# Altium schematic sheet Sheet1.SchDoc
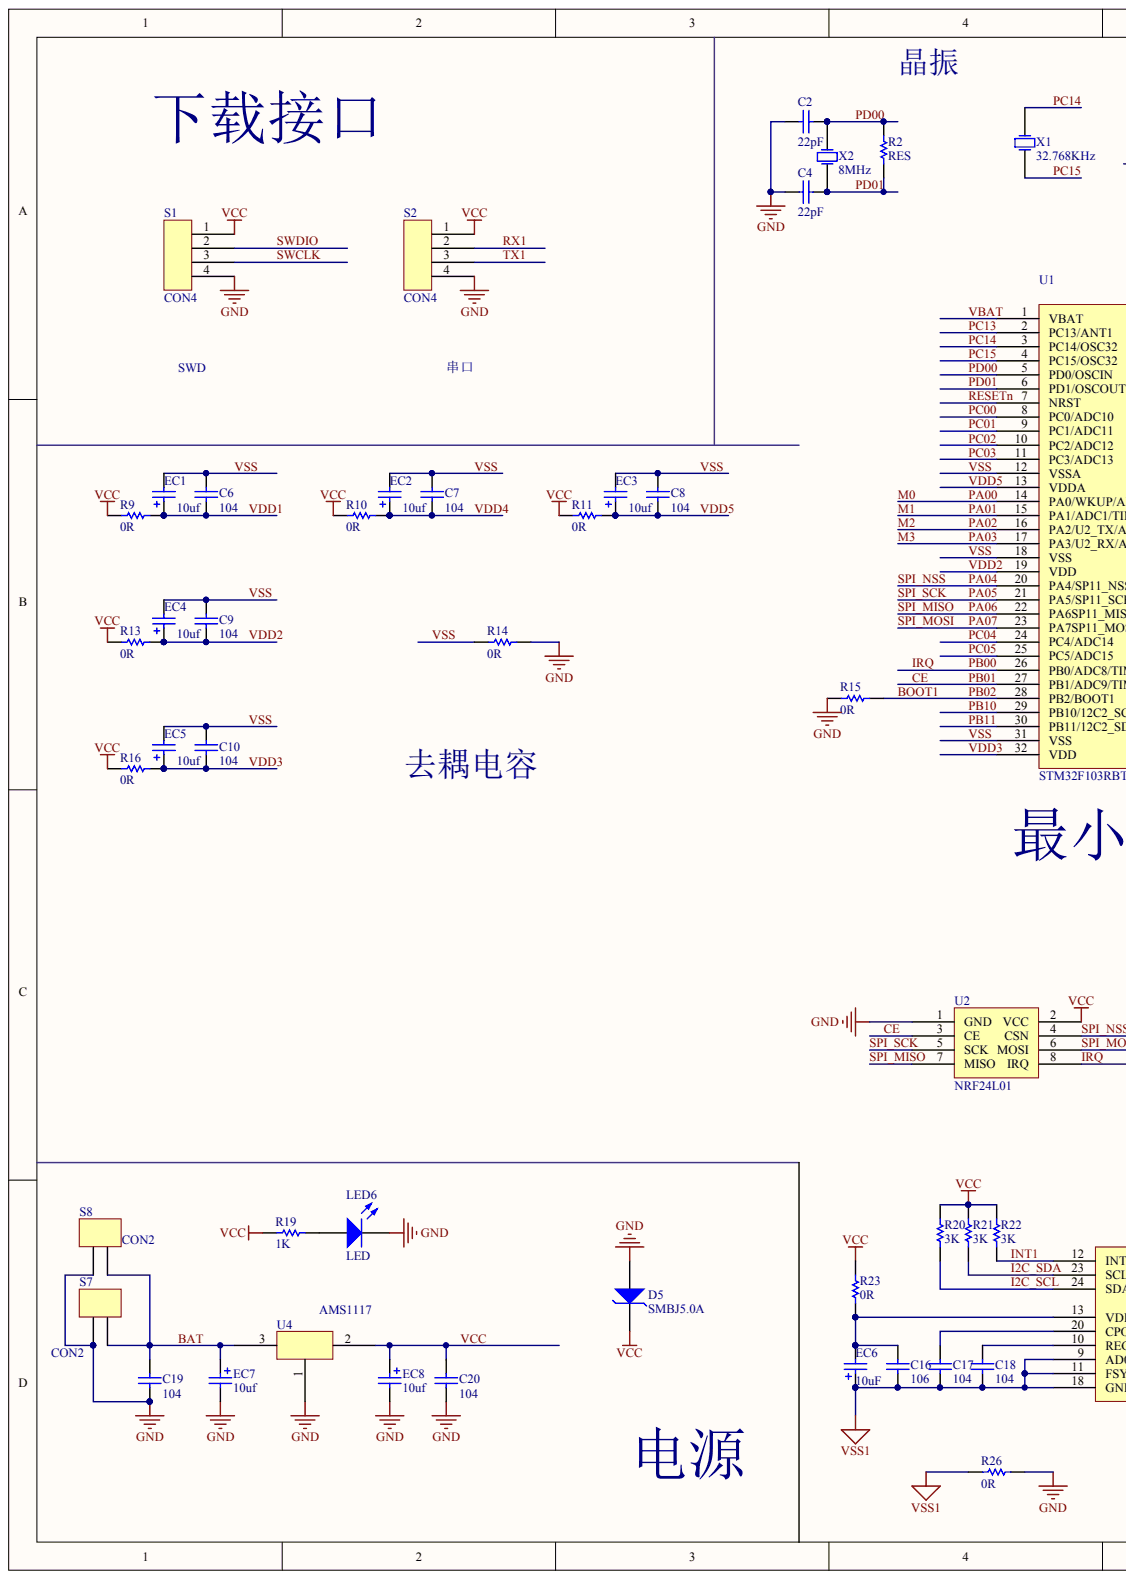
[diagram p0: Sheet1.SchDoc, left]
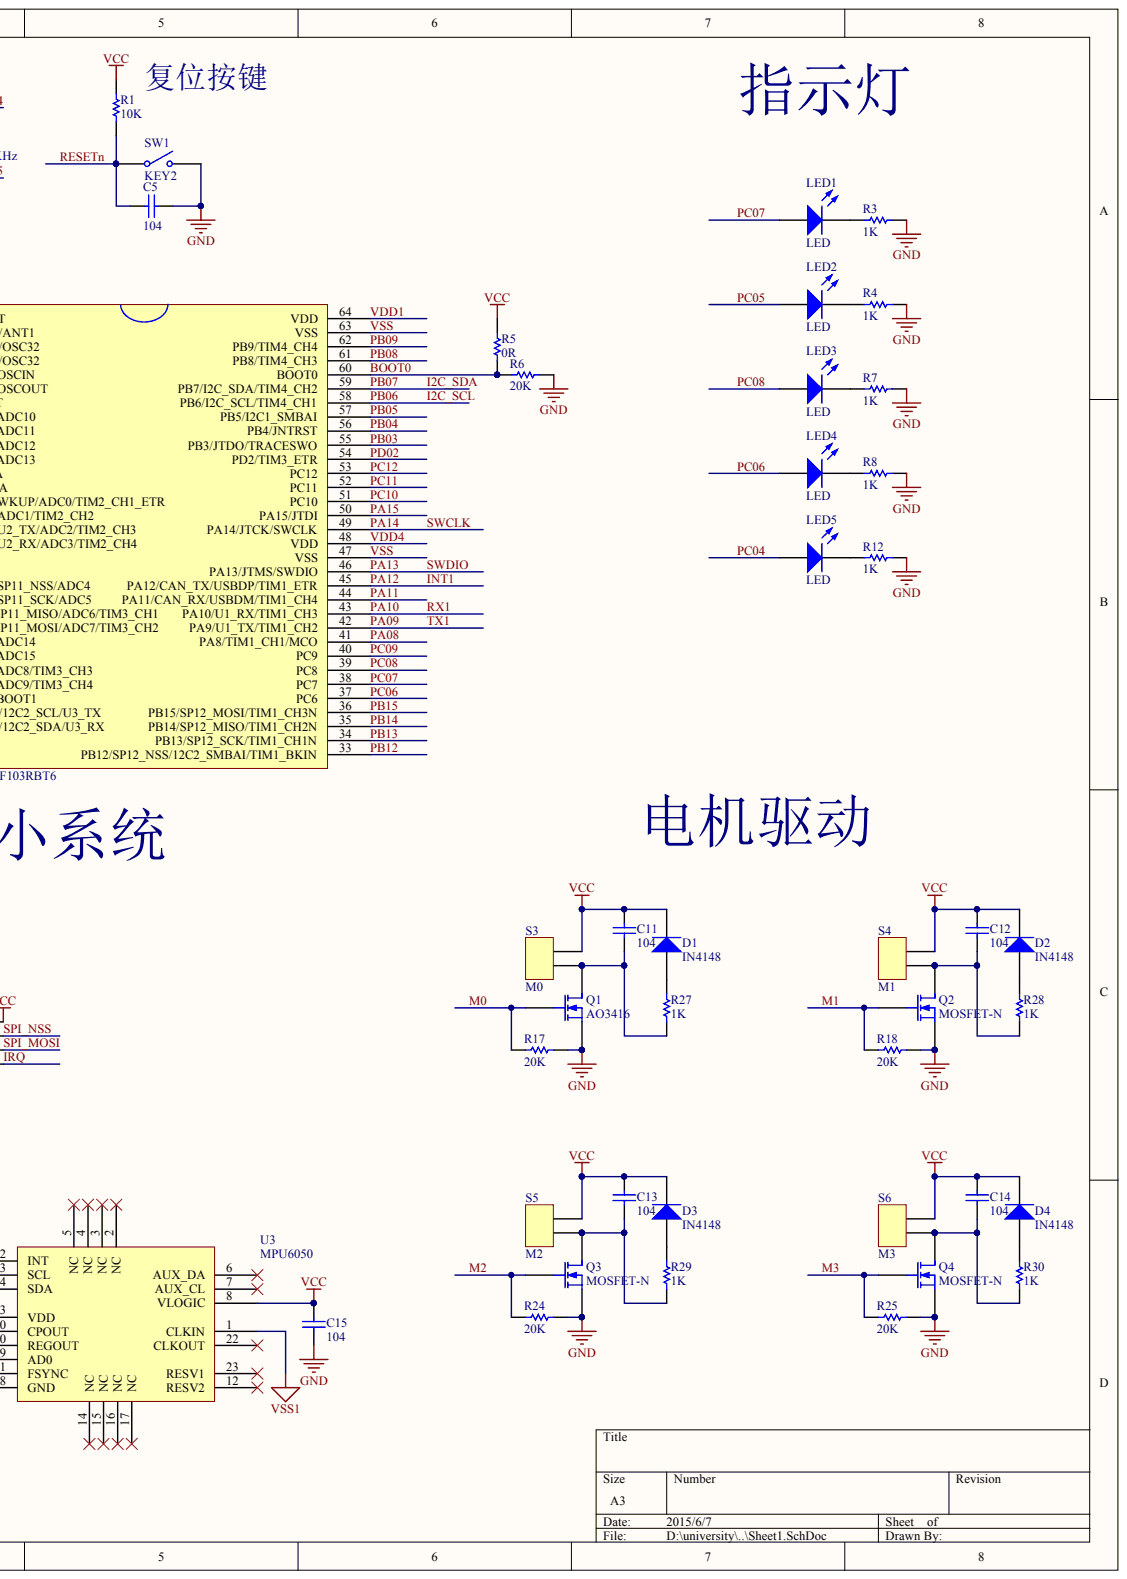
[diagram p1: Sheet1.SchDoc, right]

## Parts
C2  22pF
C4  22pF
C5  104
C6  104
C7  104
C8  104
C9  104
C10  104
C11  104
C12  104
C13  104
C14  104
C15  104
C16  106
C17  104
C18  104
C19  104
C20  104
D1  IN4148
D2  IN4148
D3  IN4148
D4  IN4148
D5  SMBJ5.0A
EC1  10uf
EC2  10uf
EC3  10uf
EC4  10uf
EC5  10uf
EC6  10uF
EC7  10uf
EC8  10uf
LED1  LED
LED2  LED
LED3  LED
LED4  LED
LED5  LED
LED6  LED
Q1  AO3416
Q2  MOSFET-N
Q3  MOSFET-N
Q4  MOSFET-N
R1  10K
R2  RES
R3  1K
R4  1K
R5  0R
R6  20K
R7  1K
R8  1K
R9  0R
R10  0R
R11  0R
R12  1K
R13  0R
R14  0R
R15  0R
R16  0R
R17  20K
R18  20K
R19  1K
R20  3K
R21  3K
R22  3K
R23  0R
R24  20K
R25  20K
R26  0R
R27  1K
R28  1K
R29  1K
R30  1K
S1  CON4
S2  CON4
S3  M0
S4  M1
S5  M2
S6  M3
S7  CON2
S8  CON2
SW1  KEY2
U1  STM32F103RBT6
U2  NRF24L01
U3  MPU6050
U4  AMS1117
X1  32.768KHz
X2  8MHz

## Nets
GND: C2.1 C4.1 C5.2 C15.2 C19.2 C20.2 D5.1 EC7.2 EC8.2 LED6.2 Q1.3 Q2.3 Q3.3 Q4.3 R3.2 R4.2 R6.2 R7.2 R8.2 R12.2 R14.2 R15.1 R17.2 R18.2 R24.2 R25.2 R26.2 S1.4 S2.4 S7.2 S8.2 SW1.2 U2.1(GND) U4.1
VSS1: C16.1 C17.2 C18.2 EC6.1 R26.1 U3.1(CLKIN) U3.9(AD0) U3.11(FSYNC) U3.18(GND)
BAT: C19.1 EC7.1 S7.1 S8.1 U4.3
BOOT0: R5.1 R6.1 U1.60(BOOT0)
BOOT1: R15.2 U1.28(PB2/BOOT1)
CE: U1.27(PB1/ADC9/TIM3_CH4) U2.3(CE)
I2C_SCL: R20.1 U1.58(PB6/I2C_SCL/TIM4_CH1) U3.24(SDA)
I2C_SDA: R21.1 U1.59(PB7/I2C_SDA/TIM4_CH2) U3.23
INT1: R22.1 U1.45(PA12/CAN_TX/USBDP/TIM1_ETR) U3.12
IRQ: U1.26(PB0/ADC8/TIM3_CH3) U2.8(IRQ)
M0: Q1.2 R17.1 U1.14(PA0/WKUP/ADC0/TIM2_CH1_ETR)
M1: Q2.2 R18.1 U1.15(PA1/ADC1/TIM2_CH2)
M2: Q3.2 R24.1 U1.16(PA2/U2_TX/ADC2/TIM2_CH3)
M3: Q4.2 R25.1 U1.17(PA3/U2_RX/ADC3/TIM2_CH4)
PA04: U1.20(PA4/SP11_NSS/ADC4) U2.4(CSN)
PA05: U1.21(PA5/SP11_SCK/ADC5) U2.5(SCK)
PA06: U1.22(PA6SP11_MISO/ADC6/TIM3_CH1) U2.7(MISO)
PA07: U1.23(PA7SP11_MOSI/ADC7/TIM3_CH2) U2.6(MOSI)
PA08: U1.41(PA8/TIM1_CH1/MCO)
PA09: S2.3 U1.42(PA9/U1_TX/TIM1_CH2)
PA10: S2.2 U1.43(PA10/U1_RX/TIM1_CH3)
PA11: U1.44(PA11/CAN_RX/USBDM/TIM1_CH4)
PA13: S1.2 U1.46(PA13/JTMS/SWDIO)
PA14: S1.3 U1.49(PA14/JTCK/SWCLK)
PA15: U1.50(PA15/JTDI)
PB03: U1.55(PB3/JTDO/TRACESWO)
PB04: U1.56(PB4/JNTRST)
PB05: U1.57(PB5/I2C1_SMBAI)
PB08: U1.61(PB8/TIM4_CH3)
PB09: U1.62(PB9/TIM4_CH4)
PB10: U1.29(PB10/12C2_SCL/U3_TX)
PB11: U1.30(PB11/12C2_SDA/U3_RX)
PB12: U1.33(PB12/SP12_NSS/12C2_SMBAI/TIM1_BKIN)
PB13: U1.34(PB13/SP12_SCK/TIM1_CH1N)
PB14: U1.35(PB14/SP12_MISO/TIM1_CH2N)
PB15: U1.36(PB15/SP12_MOSI/TIM1_CH3N)
PC00: U1.8(PC0/ADC10)
PC01: U1.9(PC1/ADC11)
PC02: U1.10(PC2/ADC12)
PC03: U1.11(PC3/ADC13)
PC04: LED5.1 U1.24(PC4/ADC14)
PC05: LED2.1 U1.25(PC5/ADC15)
PC06: LED4.1 U1.37(PC6)
PC07: LED1.1 U1.38(PC7)
PC08: LED3.1 U1.39(PC8)
PC09: U1.40(PC9)
PC10: U1.51(PC10)
PC11: U1.52(PC11)
PC12: U1.53(PC12)
PC13: U1.2(PC13/ANT1)
PC14: U1.3(PC14/OSC32) X1.2
PC15: U1.4(PC15/OSC32) X1.1
PD00: C2.2 R2.2 U1.5(PD0/OSCIN) X2.2
PD01: C4.2 R2.1 U1.6(PD1/OSCOUT) X2.1
PD02: U1.54(PD2/TIM3_ETR)
RESETN: C5.1 R1.1 SW1.1 U1.7(NRST)
VBAT: U1.1(VBAT)
VCC: C11.2 C12.2 C13.2 C14.2 C15.1 C20.1 D1.2 D2.2 D3.2 D4.2 D5.2 EC8.1 R1.2 R5.2 R9.1 R10.1 R11.1 R13.1 R16.1 R19.1 R20.2 R21.2 R22.2 R23.2 S1.1 S2.1 S3.1 S4.1 S5.1 S6.1 U2.2(VCC) U3.8(VLOGIC) U4.2
VDD1: C6.1 EC1.1 R9.2 U1.64(VDD)
VDD2: C9.1 EC4.1 R13.2 U1.19(VDD)
VDD3: C10.1 EC5.1 R16.2 U1.32(VDD)
VDD4: C7.1 EC2.1 R10.2 U1.48(VDD)
VDD5: C8.1 EC3.1 R11.2 U1.13(VDDA)
VSS: C6.2 C7.2 C8.2 C9.2 C10.2 EC1.2 EC2.2 EC3.2 EC4.2 EC5.2 R14.1 U1.12(VSSA) U1.18(VSS) U1.31(VSS) U1.47(VSS) U1.63(VSS)
NetC11_1: C11.1 Q1.1 R27.1 S3.2
NetC12_1: C12.1 Q2.1 R28.1 S4.2
NetC13_1: C13.1 Q3.1 R29.1 S5.2
NetC14_1: C14.1 Q4.1 R30.1 S6.2
NetC16_2: C16.2 EC6.2 R23.1 U3.13(VDD)
NetC17_1: C17.1 U3.20(CPOUT)
NetC18_1: C18.1 U3.10(REGOUT)
NetD1_1: D1.1 R27.2
NetD2_1: D2.1 R28.2
NetD3_1: D3.1 R29.2
NetD4_1: D4.1 R30.2
NetLED1_2: LED1.2 R3.1
NetLED2_2: LED2.2 R4.1
NetLED3_2: LED3.2 R7.1
NetLED4_2: LED4.2 R8.1
NetLED5_2: LED5.2 R12.1
NetLED6_1: LED6.1 R19.2

Not connected: U3 2(NC) 3(NC) 4(NC) 5(NC) 6(AUX_DA) 7(AUX_CL) 14(NC) 15(NC) 16(NC) 17(NC) 22(CLKOUT)

## Library data of the parts
C2 C4-C20 D1-D5 EC1-EC8 LED1-LED6 Q1-Q4 R1-R30 S1-S8 SW1 U1-U4 X1 X2 = (no symbol name)
  Q1-Q4: Published: 8-Jun-2000; LatestRevisionDate: 17-Jul-2002; LatestRevisionNote: Re-released for DXP Platform.; PackageVersion: 1995/1996; PackageReference: E3; PackageDescription: TO, Flat Index; 3 In-Line, Axial Leads; Body Dia. 4.6mm; Lead Dia. 0.5 mm (max); Publisher: Altium Limited
